# Altium schematic sheet BREEZE Pro Power V1.SchDoc
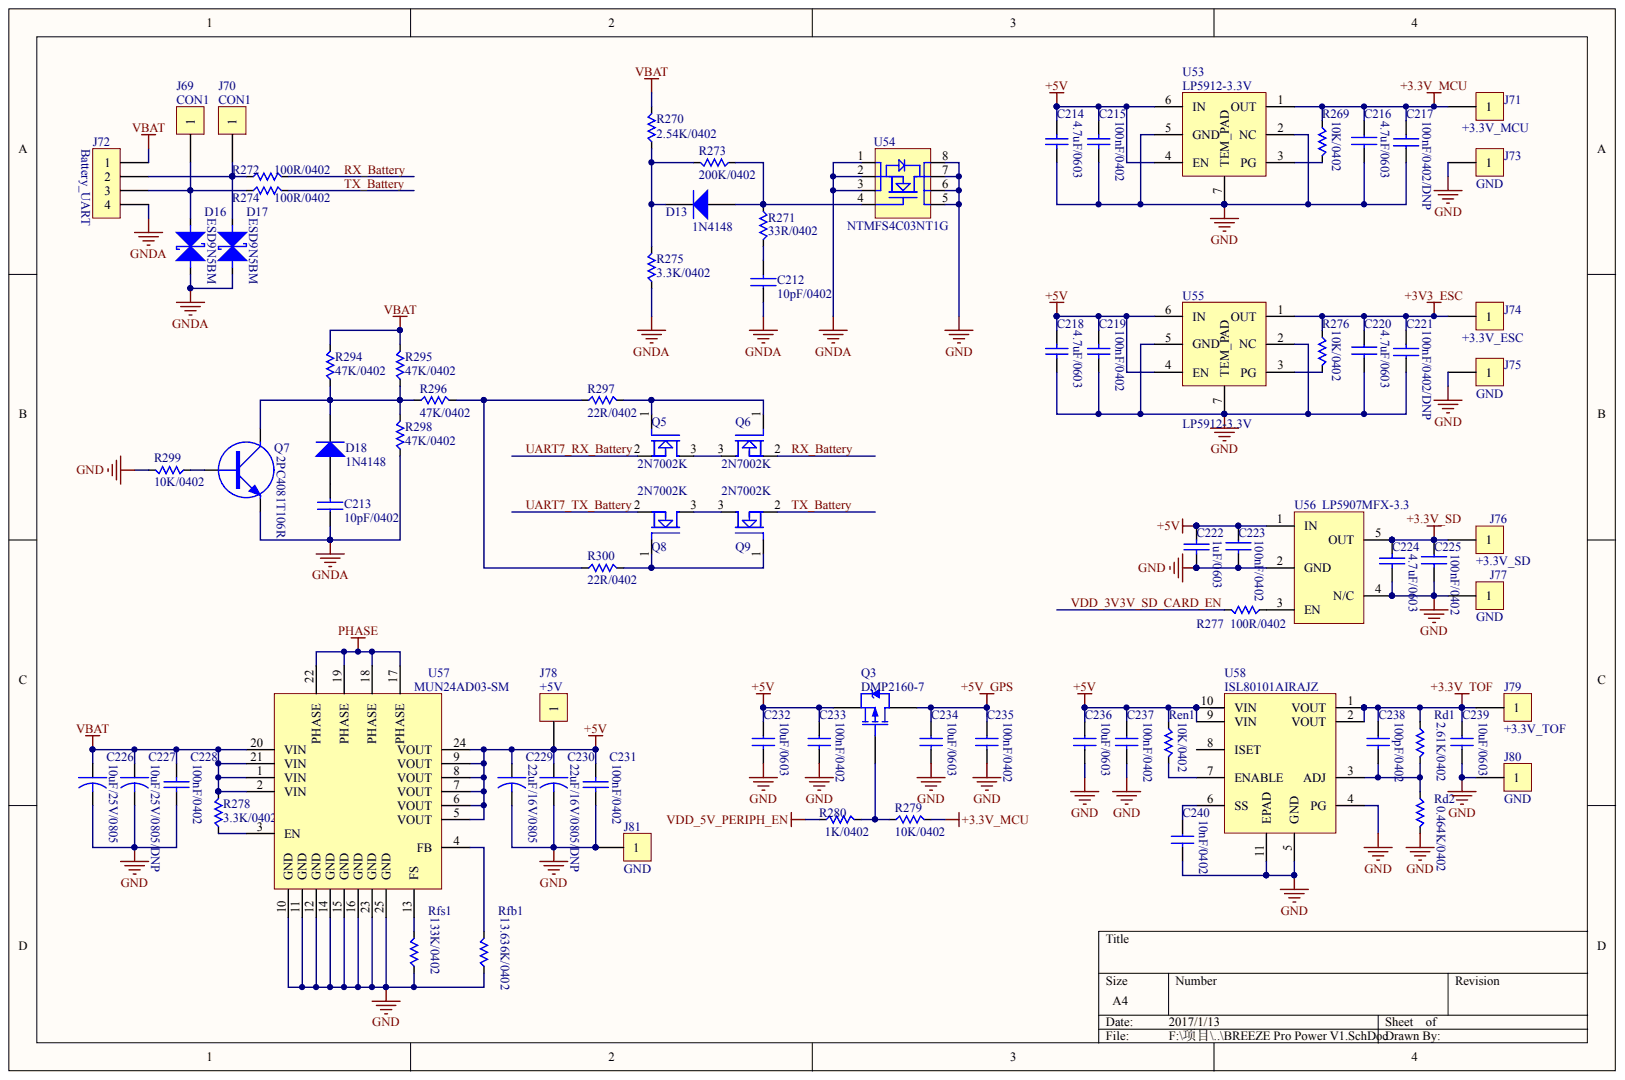
[diagram p0: BREEZE Pro Power V1.SchDoc]

## Parts
C212  10pF/0402
C213  10pF/0402
C214  4.7uF/0603
C215  100nF/0402
C216  4.7uF/0603
C217  100nF/0402/DNP
C218  4.7uF/0603
C219  100nF/0402
C220  4.7uF/0603
C221  100nF/0402/DNP
C222  1uF/0603
C223  100nF/0402
C224  4.7uF/0603
C225  100nF/0402
C226  10uF/25V/0805
C227  10uF/25V/0805/DNP
C228  100nF/0402
C229  22uF/16V/0805
C230  22uF/16V/0805/DNP
C231  100nF/0402
C232  10uF/0603
C233  100nF/0402
C234  10uF/0603
C235  100nF/0402
C236  10uF/0603
C237  100nF/0402
C238  100pF/0402
C239  10uF/0603
C240  10nF/0402
D13  1N4148
D16  ESD9N5BM
D17  ESD9N5BM
D18  1N4148
J69  CON1
J70  CON1
J71  +3.3V_MCU
J72  Battery_UART
J73  GND
J74  +3.3V_ESC
J75  GND
J76  +3.3V_SD
J77  GND
J78  +5V
J79  +3.3V_TOF
J80  GND
J81  GND
Q3  DMP2160-7
Q5  2N7002K
Q6  2N7002K
Q7  2PC4081T106R
Q8  2N7002K
Q9  2N7002K
R269  10K/0402
R270  2.54K/0402
R271  33R/0402
R272  100R/0402
R273  200K/0402
R274  100R/0402
R275  3.3K/0402
R276  10K/0402
R277  100R/0402
R278  3.3K/0402
R279  10K/0402
R280  1K/0402
R294  47K/0402
R295  47K/0402
R296  47K/0402
R297  22R/0402
R298  47K/0402
R299  10K/0402
R300  22R/0402
Rd1  2.61K/0402
Rd2  0.464K/0402
Ren1  10K/0402
Rfb1  13.636K/0402
Rfs1  133K/0402
U53  LP5912-3.3V
U54  NTMFS4C03NT1G
U55  LP5912-3.3V
U56  LP5907MFX-3.3
U57  MUN24AD03-SM
U58  ISL80101AIRAJZ

## Nets
+3.3V_MCU: C216.1 C217.2 J71.1 R269.1 R279.2 U53.1(OUT)
+3.3V_SD: C224.1 C225.1 J76.1 U56.5(OUT)
+3.3V_TOF: C238.1 C239.1 J79.1 Rd1.1 U58.1(VOUT) U58.2(VOUT)
+3V3_ESC: C220.1 C221.2 J74.1 R276.1 U55.1(OUT)
+5V: C214.1 C215.1 C218.1 C219.1 C222.1 C223.1 C229.1 C230.1 C231.2 C232.1 C233.1 C236.1 C237.1 J78.1 Q3.2 Ren1.1 U53.4(EN) U53.6(IN) U55.4(EN) U55.6(IN) U56.1(IN) U57.5(VOUT) U57.6(VOUT) U57.7(VOUT) U57.8(VOUT) U57.9(VOUT) U57.24(VOUT) U58.9(VIN) U58.10(VIN)
+5V_GPS: C234.1 C235.1 Q3.3
GND: C214.2 C215.2 C216.2 C217.1 C218.2 C219.2 C220.2 C221.1 C222.2 C223.2 C224.2 C225.2 C226.2 C227.2 C228.1 C229.2 C230.2 C231.1 C232.2 C233.2 C234.2 C235.2 C236.2 C237.2 C239.2 C240.2 J73.1 J75.1 J77.1 J80.1 J81.1 R299.2 Rd2.2 Rfb1.1 Rfs1.1 U53.2(NC) U53.5(GND) U53.7(TEM_PAD) U54.5 U54.6 U54.7 U54.8 U55.2(NC) U55.5(GND) U55.7(TEM_PAD) U56.2(GND) U56.4(N/C) U57.10(GND) U57.11(GND) U57.12(GND) U57.14(GND) U57.15(GND) U57.16(GND) U57.23(GND) U57.25(GND) U58.4(PG) U58.5(GND) U58.11(EPAD)
GNDA: C212.1 C213.1 D16.1 D17.1 J72.4 Q7.2 R275.1 R298.1 U54.1 U54.2 U54.3
PHASE: U57.17(PHASE) U57.18(PHASE) U57.19(PHASE) U57.22(PHASE)
VBAT: C226.1 C227.1 C228.2 J72.1 R270.2 R278.2 R294.2 R295.2 U57.1(VIN) U57.2(VIN) U57.20(VIN) U57.21(VIN)
VDD_5V_PERIPH_EN: R280.1
RX_BATTERY: Q6.2 R272.2
TX_BATTERY: Q9.2 R274.2
UART7_RX_BATTERY: Q5.2
UART7_TX_BATTERY: Q8.2
VDD_3V3V_SD_CARD_EN: R277.2
NetC212_2: C212.2 R271.2
NetC213_2: C213.2 D18.1
NetC238_2: C238.2 Rd1.2 Rd2.1 U58.3(ADJ)
NetC240_1: C240.1 U58.6(SS)
NetD13_1: D13.1 R271.1 R273.1 U54.4
NetD13_2: D13.2 R270.1 R273.2 R275.2
NetD16_2: D16.2 J69.1 J72.3 R274.1
NetD17_2: D17.2 J70.1 J72.2 R272.1
NetD18_2: D18.2 Q7.3 R294.1 R295.1 R296.1 R298.2
NetQ3_1: Q3.1 R279.1 R280.2
NetQ5_1: Q5.1 Q6.1 R297.2
NetQ5_3: Q5.3 Q6.3
NetQ7_1: Q7.1 R299.1
NetQ8_1: Q8.1 Q9.1 R300.2
NetQ8_3: Q8.3 Q9.3
NetR269_2: R269.2 U53.3(PG)
NetR276_2: R276.2 U55.3(PG)
NetR277_1: R277.1 U56.3(EN)
NetR278_1: R278.1 U57.3(EN)
NetR296_2: R296.2 R297.1 R300.1
NetRen1_2: Ren1.2 U58.7(ENABLE)
NetRfb1_2: Rfb1.2 U57.4(FB)
NetRfs1_2: Rfs1.2 U57.13(FS)
N00009:

Not connected: U58 8(ISET)

## Library data of the parts
C215 = (no symbol name): 材质: X7R; 名称: 贴片电容; value: 100nF/0402/DNP; : 50V
C216 C217 C220 C221 C223 C225 = (no symbol name): Text Field1: 20; Text Field2: 10
C212-C214 C218 C219 C222 C224 C226-C240 D13 D16-D18 J69-J81 Q3 Q5-Q9 R269-R280 R294-R300 Rd1 Rd2 Ren1 Rfb1 Rfs1 U53-U58 = (no symbol name)
  C214 C218: Published: 8-Jun-2000; LatestRevisionDate: 17-Jul-2002; LatestRevisionNote: Re-released for DXP Platform.; PackageReference: RAD-0.3; PackageDescription: Radial Cap, Thru-Hole; 2 Leads; 0.3 in Pin Spacing
  D13 D18: Published: 8-Jun-2000; LatestRevisionDate: 17-Jul-2002; LatestRevisionNote: Re-released for DXP Platform.; PackageVersion: Sep-1996; PackageReference: SMC; PackageDescription: DO-214-AB/SMC; 2 C-Bend Leads; Body 7.9 x 5.9 mm, inc. leads (LxW); Code_JEDEC: DO-214-AB
  J72: : Horrizen 的接口使用转接线
  C214 C218 D13 D18: Publisher: Altium Limited
